# Altium schematic sheet Smartwatch_Schematic.SchDoc
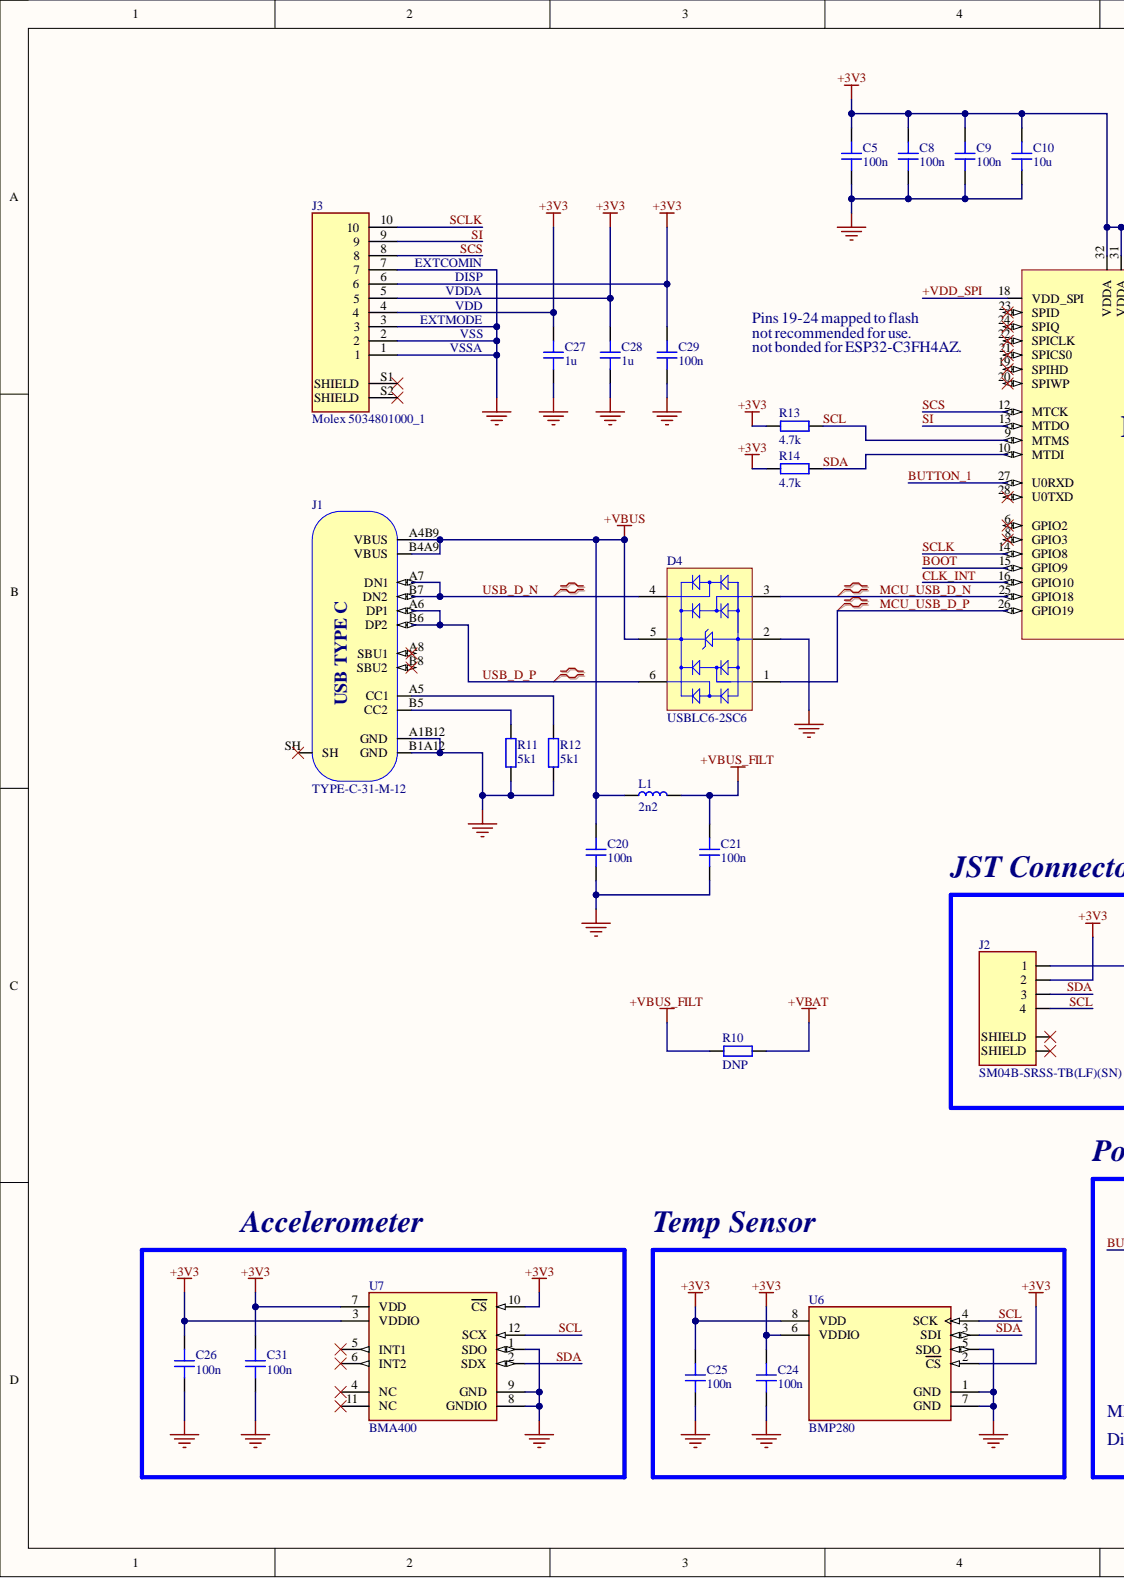
[diagram p0: Smartwatch_Schematic.SchDoc, left]
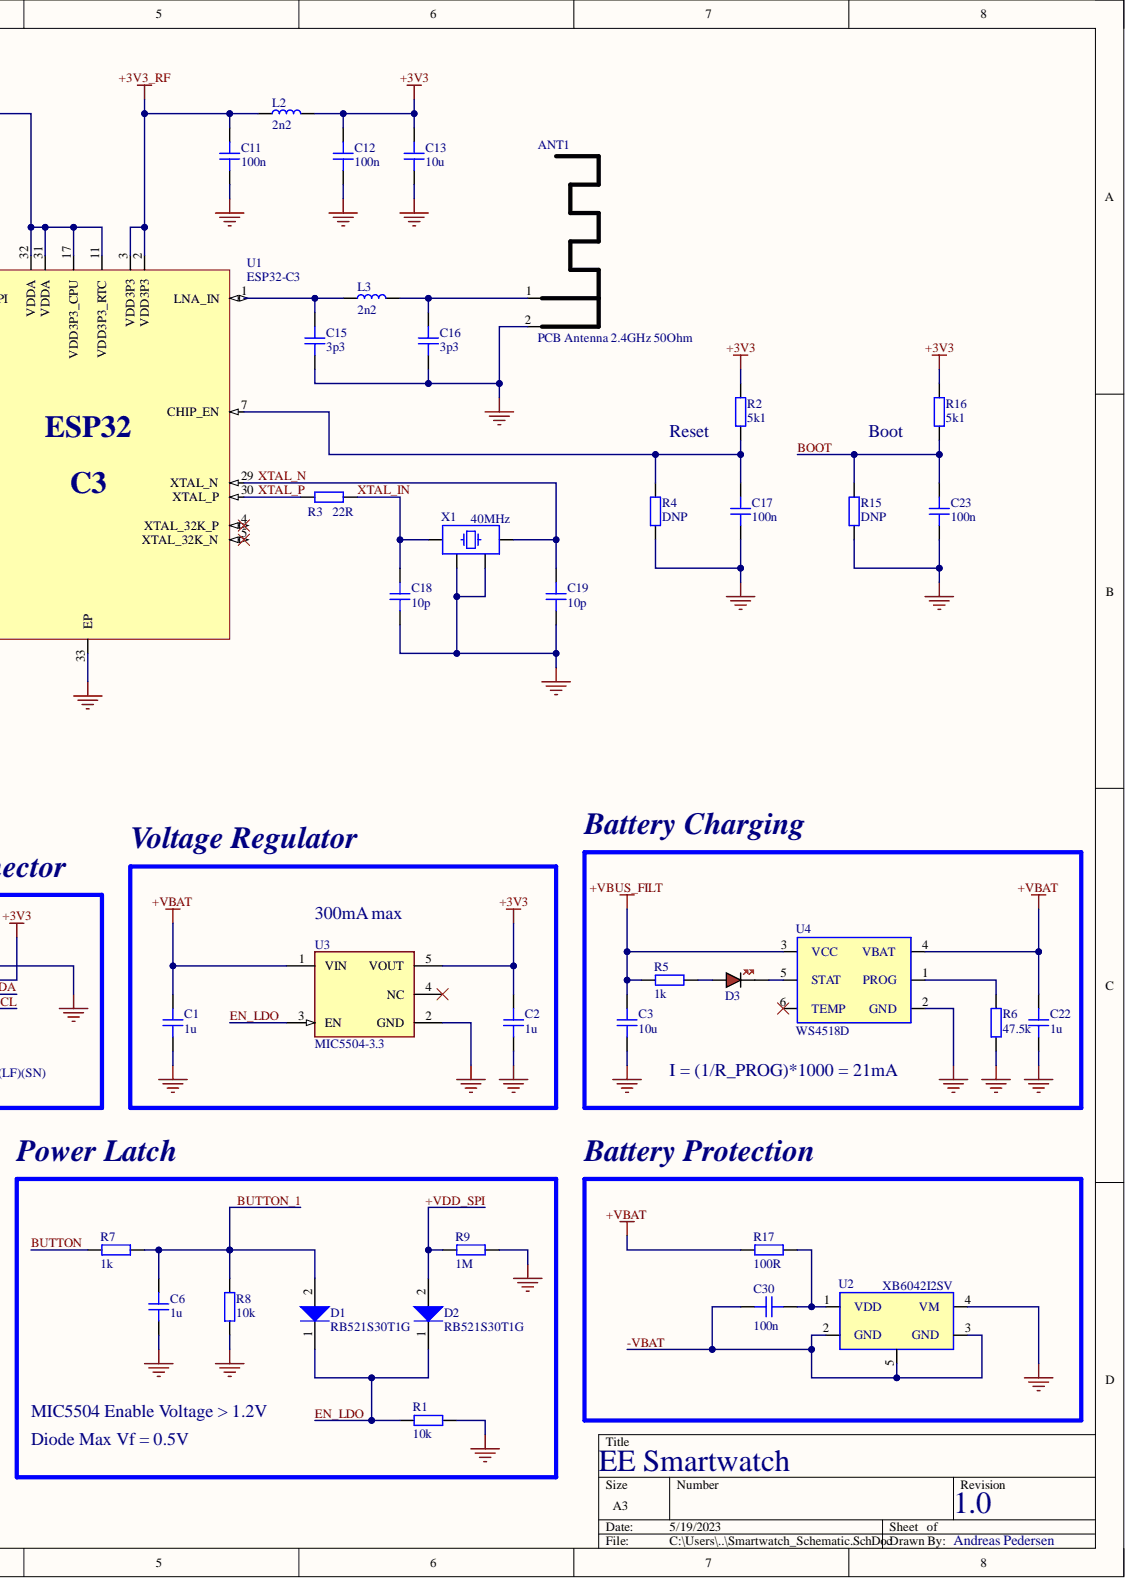
[diagram p1: Smartwatch_Schematic.SchDoc, right]

## Parts
ANT1  PCB Antenna 2.4GHz 50Ohm  [ANT_IFA_USB_2_4GHZ_50R]  (no BOM)
C1  1u  [CAP_0603]
C2  1u  [CAP_0603]
C3  10u  [CAP_0603]
C5  100n  [CAP_0402]
C6  1u  [CAP_0402]
C8  100n  [CAP_0402]
C9  100n  [CAP_0402]
C10  10u  [CAP_0603]
C11  100n  [CAP_0402]
C12  100n  [CAP_0402]
C13  10u  [CAP_0603]
C15  3p3  [CAP_0402]
C16  3p3  [CAP_0402]
C17  100n  [CAP_0402]
C18  10p  [CAP_0402]
C19  10p  [CAP_0402]
C20  100n  [CAP_0402]
C21  100n  [CAP_0402]
C22  1u  [CAP_0603]
C23  100n  [CAP_0402]
C24  100n  [CAP_0402]
C25  100n  [CAP_0402]
C26  100n  [CAP_0402]
C27  1u  [CAP_0402]
C28  1u  [CAP_0402]
C29  100n  [CAP_0402]
C30  100n  [CAP_0402]
C31  100n  [CAP_0402]
D1  RB521S30T1G  [ONSC-SOD-523-2-502-01_V]
D2  RB521S30T1G  [ONSC-SOD-523-2-502-01_V]
D3  RED  [LED_0603_RED]  (value not drawn)
D4  USBLC6-2SC6  [STM-SOT23-6L_V]
J1  TYPE-C-31-M-12  [TYPE-C-31-M-12]
J2  SM04B-SRSS-TB(LF)(SN)  [JST_SM04B-SRSS-TB(LF)(SN)]
J3  Molex 5034801000_1  MF: Molex  [MOLEX_5034801000]
L1  2n2  [IND_0603]
L2  2n2  [IND_0402]
L3  2n2  [IND_0402]
R1  10k  [RES_0402]
R2  5k1  [RES_0402]
R3  22R  [RES_0402]
R4  DNP  [RES_1206]
R5  1k  [RES_0402]
R6  47.5k  [RES_0402]
R7  1k  [RES_0402]
R8  10k  [RES_0402]
R9  1M  [RES_0402]
R10  DNP  [RES_1206]
R11  5k1  [RES_0402]
R12  5k1  [RES_0402]
R13  4.7k  [RES_0402]
R14  4.7k  [RES_0402]
R15  DNP  [RES_1206]
R16  5k1  [RES_0402]
R17  100R  [RES_0402]
U1  ESP32-C3  [QFN32-5x5]
U2  XB6042I2SV  [XDFN-4_L1.0-W1.0-P0.65-BL-EP]
U3  MIC5504-3.3  Max Output Current: 300mA  [FP-SOT23-5LD-PL-1-MFG]
U4  WS4518D  [DFN2x2-6L]
U6  BMP280  [FP-BMP280-MFG]
U7  BMA400  [FP-BMA400-MFG]
X1  40MHz  [XTAL_2520]

## Nets
+3V3: C2.2 C5.2 C8.2 C9.2 C10.2 C12.2 C13.2 C24.2 C25.2 C26.2 C27.2 C28.2 C29.2 C31.2 J2.2 J3.4 J3.5 J3.6 L2.2 R2.1 R13.1 R14.1 R16.1 U1.11(VDD3P3_RTC) U1.17(VDD3P3_CPU) U1.31(VDDA) U1.32(VDDA) U3.5(VOUT) U6.2(CS) U6.6(VDDIO) U6.8(VDD) U7.3(VDDIO) U7.7(VDD) U7.10(CS)
+3V3_RF: C11.2 L2.1 U1.2(VDD3P3) U1.3(VDD3P3)
+VBAT: C1.2 C22.2 R10.2 R17.2 U3.1(VIN) U4.4(VBAT)
+VBUS: C20.2 D4.5 J1.A4B9(VBUS) J1.B4A9(VBUS) L1.1
+VBUS_FILT: C3.2 C21.2 L1.2 R5.2 R10.1 U4.3(VCC)
GND: ANT1.2 C1.1 C2.1 C3.1 C5.1 C6.1 C8.1 C9.1 C10.1 C11.1 C12.1 C13.1 C15.1 C16.1 C17.1 C18.1 C19.1 C20.1 C21.1 C22.1 C23.1 C24.1 C25.1 C26.1 C27.1 C28.1 C29.1 C31.1 D4.2 J1.A1B12(GND) J1.B1A12(GND) J2.1 J3.1 J3.2 J3.3 J3.7 R1.1 R4.2 R6.1 R8.1 R9.1 R11.1 R12.1 R15.2 U1.33(EP) U2.4(VM) U3.2(GND) U4.2(GND) U6.1(GND) U6.5(SDO) U6.7(GND) U7.1(SDO) U7.8(GNDIO) U7.9(GND) X1.2 X1.4
+VDD_SPI: D2.2 R9.2 U1.18(VDD_SPI)
-VBAT: C30.1 U2.2(GND) U2.3(GND) U2.5
BOOT: C23.2 R15.1 R16.2 U1.15(GPIO9)
BUTTON: R7.2
BUTTON_1: C6.2 D1.2 R7.1 R8.2 U1.27(U0RXD)
CLK_INT: U1.16(GPIO10)
EN_LDO: D1.1 D2.1 R1.2 U3.3(EN)
MCU_USB_D_N: D4.3 U1.25(GPIO18)
MCU_USB_D_P: D4.1 U1.26(GPIO19)
SCL: J2.4 R13.2 U1.9(MTMS) U6.4(SCK) U7.12(SCX)
SCLK: J3.10 U1.14(GPIO8)
SCS: J3.8 U1.12(MTCK)
SDA: J2.3 R14.2 U1.10(MTDI) U6.3(SDI) U7.2(SDX)
SI: J3.9 U1.13(MTDO)
USB_D_N: D4.4 J1.A7(DN1) J1.B7(DN2)
USB_D_P: D4.6 J1.A6(DP1) J1.B6(DP2)
XTAL_IN: C18.2 R3.1 X1.1
XTAL_N: C19.2 U1.29(XTAL_N) X1.3
XTAL_P: R3.2 U1.30(XTAL_P)
NetANT1_1: ANT1.1 C16.2 L3.2
NetC15_2: C15.2 L3.1 U1.1(LNA_IN)
NetC17_2: C17.2 R2.2 R4.1 U1.7(CHIP_EN)
NetC30_2: C30.2 R17.1 U2.1(VDD)
NetD3_A: D3.A R5.1
NetD3_K: D3.K U4.5(STAT)
NetJ1_A5: J1.A5(CC1) R12.2
NetJ1_B5: J1.B5(CC2) R11.2
NetR6_2: R6.2 U4.1(PROG)

Not connected: J1 A8(SBU1) B8(SBU2) SH; J2 S1(SHIELD) S2(SHIELD); J3 S1(SHIELD) S2(SHIELD); U1 4(XTAL_32K_P) 5(XTAL_32K_N) 6(GPIO2) 8(GPIO3) 19(SPIHD) 20(SPIWP) 21(SPICS0) 22(SPICLK) 23(SPID) 24(SPIQ) 28(U0TXD); U3 4(NC); U4 6(TEMP); U7 4(NC) 5(INT1) 6(INT2) 11(NC)

## Library data of the parts
ANT1 = ANT_IFA_USB_2_4GHZ_50R: Inverted F 2.4GHz ISM PCB Antenna 50 Ohm; ComponentLink1Description: Datasheet; ComponentLink1URL: https://www.ti.com/lit/an/swra117d/swra117d.pdf; Library Name: Schematic-Lib-PhilsLab_V2.SchLib
C1-C3 C10 C13 C22 = CAP_0603_10V: Capacitor SMD 0603 10V; Component Kind: Standard
  C1-C3 C22: Library Name: Schematic-Lib-PhilsLab.SchLib
  C10 C13: Library Name: Schematic-Lib-PhilsLab_V2.SchLib
C5 C6 C8 C9 C11 C12 C15-C19 C23-C31 = CAP_0402_10V: Capacitor SMD 0402 10V; Component Kind: Standard; Library Name: Schematic-Lib-PhilsLab_V2.SchLib
C20 C21 = CAP_0603_10V: Capacitor SMD 0402 10V; Component Kind: Standard; Library Name: Schematic-Lib-PhilsLab_V2.SchLib
D1 D2 = CMP-1051-00018-1: Schottky Barrier Diode, 2-Pin SOD-523, Pb-Free, Tape and Reel; Manufacturer: On Semiconductor; Configuration: Single; IFSM Max (A): 1; Component Kind: Standard; ComponentLink1Description: Manufacturer URL; ComponentLink1URL: http://www.onsemi.com/; ComponentLink2Description: Datasheet; ComponentLink2URL: http://www.onsemi.cn/pub_link/Collateral/RB521S30T1-D.PDF; ComponentLink3Description: Package Specification; ComponentLink3URL: http://www.onsemi.com/pub_link/Collateral/502-01.PDF; DatasheetVersion: Rev. 8; Library Name: Altium Content Vault; Mounting Technology: Surface Mount; PackageDescription: 2-Pin SOD, Body 1.2 x 0.8 mm; PackageReference: SOD-523-2-502-01; PackageVersion: Rev. E, 09/2010; Packing: Tape and Reel; PartNumber: RB521S30T1G; RoHS: TRUE
D3 = LED_0603_RED: LED 0603 RED SMD; Component Kind: Standard; Library Name: Schematic-Lib-PhilsLab.SchLib
D4 = CMP-2000-05378-1: Very low capacitance ESD protection, 3.5 pF, -40 to 125 degC, 6-Pin SOT23, RoHS, Tape and Reel; Manufacturer: STMicroelectronics; Capacitance Value: 3.5pF; Clamping Voltage: 17V; Configuration: Dual; Direction Type: Uni-Directional; Leakage Current: 0.15uA; Number of Elements: 1; Peak Pulse Current: 5A; Product Depth (mm): 1.75mm; Product Height (mm): 1.3mm; Product Length (mm): 3.05mm; Rad Hardened: No; Reverse Breakdown Voltage: 6V; Reverse Stand-off Voltage: 5V; Suppressor Type: DiodeArrays; Test Current (It): 1mA; Clamping Voltage (Max): 17V; Component Kind: Standard; Datasheet URL: http://www.st.com/st-web-ui/static/active/en/resource/technical/document/datasheet/CD00050750.pdf; Datasheet Version: Rev. 5, 10/2011; Leakage Current (Max): 0.15uA; Library Name: Altium Content Vault; Manufacturer URL: http://www.st.com/; Mounting: SurfaceMount; Mounting Style: SurfaceMount; Operating Temp Range: -40Cto125C; Operating Temperature Classification: Automotive; Operating Temperature Max Deg. C: 125C; Operating Temperature Min Deg. C: -40C; Package / Case: SOT-23; Package Description: 6-Pin Small Outline Transistor, Body 2.925 x 1.625 mm, Pitch 0.95 mm; Package Reference: SOT23-6L; Packaging: TapeandReel; Pin Count: 6; Polarity: Uni-Directional; Working Voltage: 5V; Working Voltage (Max): 5V
J1 = TYPE-C-31-M-12: USB Type C Connector Horizontal SMT; Manufacturer: Koren Hroparts; Component Kind: Standard; Manufacturer Part Number: TYPE-C-31-M-12; Library Name: Schematic-Lib-PhilsLab_V2.SchLib
J2 = SM04B-SRSS-TB(LF)(SN): SH Series 1.0 mm Pitch 2 Position Single Row Side Entry Shrouded Header; Availability: Unavailable; Component Kind: Standard; Library Name: Custom_Schematic_Lib.SchLib; MP: SM04B-SRSS-TB(LF)(SN); Package: None; Price: None
J3 = Molex 5034801000_1: 10 Position FFC, FPC Connector Contacts, Top and Bottom 0.020 _0.50mm_ Surface Mount, Right Angle; Component Kind: Standard; Digi-Key_Part_Number: WM1389CT-ND; Digi-Key_Purchase_URL: https://www.digikey.com/product-detail/en/molex/5034801000/WM1389CT-ND/2356643?utm_source=snapeda&utm_medium=aggregator&utm_campaign=symbol; Library Name: Custom_Schematic_Lib.SchLib; MP: 5034801000; Package: None; SnapEDA_Link: https://www.snapeda.com/parts/5034801000/Molex/view-part/2746786/?ref=snap
L1-L3 = IND_0603: Inductor SMD 0603; Component Kind: Standard; Library Name: Schematic-Lib-PhilsLab_V2.SchLib
R1-R3 R5-R9 R11-R14 R16 R17 = RES_0402: Resistor SMD 0402; Component Kind: Standard; Library Name: Schematic-Lib-PhilsLab_V2.SchLib
R4 R10 R15 = RES_0603: Resistor SMD 0603; Component Kind: Standard; Library Name: Schematic-Lib-PhilsLab_V2.SchLib
U1 = ESP32-C3: Ultra­Low­Power SoC with RISC­V Single­Core CPU Supporting IEEE 802.11b/g/n (2.4 GHz Wi­Fi) and Bluetooth® 5 (LE); Manufacturer: Espressif; Component Kind: Standard; LCSC: C2838500; Manufacturer Part Number: ESP32-C3; Library Name: Schematic-Lib-PhilsLab_V2.SchLib
U2 = XB6042I2SV: XySemi Battery Protection DFN1x1x0.37-4; Component Kind: Standard; Library Name: Custom_Schematic_Lib.SchLib
U3 = CMP-2000-07604-2: IC REG LINEAR 3.3V 300MA SOT23-5; Accuracy: 3%; Dropout Voltage: 380mV; Max Input Voltage: 5.5V; Min Input Voltage: 2.5V; Number of Outputs: 1; Operating Supply Current: 42uA; Output Type: Fixed; Power Supply Rejection Ratio (PSRR): 60dB; Quiescent Current: 38uA; Case/Package: SOT-23-5; Component Kind: Standard; Height: 1.1mm; Length: 2.9mm; Library Name: Altium Content Vault; Max Operating Temperature: 125°C; Min Operating Temperature: -40°C; Mount: Surface Mount; Nominal Output Voltage: 3.3V; Number of Pins: 5; Output Current: 300mA; Output Voltage: 3.3V; Packaging: Tape and Reel; REACH SVHC: No SVHC; Width: 1.6mm
U4 = WS4518D: 300mA, Single Li-ion Battery Charger DFN2x2-6L; Component Kind: Standard; Library Name: Custom_Schematic_Lib.SchLib
U6 = CMP-2000-05076-3: SENSOR PRESSURE ABS; Max Pressure: 290.08PSI; Operating Pressure: 15.95PSI; Output Type: SPI; Port Style: No Port; Pressure Type: Absolute; Case/Package: SMD/SMT; Component Kind: Standard; Lead Free: Lead Free; Library Name: Altium Content Vault; Max Operating Temperature: 85°C; Min Operating Temperature: -40°C; Number of Pins: 8; Termination: SMD/SMT
U7 = CMP-191065-000001-1: ACCELEROMETER 2-16G 12LGA; Manufacturer: Bosch Sensortec; Accel Axis: X+Y and Z; Accel Range: 2/4/8/16g; Accel Resolution: 12bits; Accel Sensitivity: 128/256/512/1024LSB/g; Max Supply Voltage: 3.6V; Min Supply Voltage: 1.2V; Operating Supply Current: 14.5uA; Sensor Output: I2C,SPI; Ambient Temperature Range High: +85°C; Ambient Temperature Range Low: -40°C; Automotive: No; Category: IC; Component Kind: Standard; ComponentLink2Description: Datasheet; ComponentLink2URL: https://ae-bst.resource.bosch.com/media/_tech/media/datasheets/BST-BMA400-DS000.pdf; Device Class L1: Sensors; Device Class L2: Motion Sensors; Device Class L3: Accelerometers; Digikey Description: ACCELEROMETER 2-16G 12LGA; Height: 1mm; Lead Free: Yes; Library Name: Altium Content Vault; Manufacturer Part Number: BMA400; Mouser Description: BMA400 Series 3.6 V ±2g/4g/8g/16g 3 Axes Ultra Low Power Accelerometer - LGA-12; Octopart: https://octopart.com/bma400-bosch+sensortec-106101410; Package: 0; RoHS: TRUE; Temperature: -40°C to +85°C; Temperature Range High: +85°C; Temperature Range Low: -40°C
X1 = XTAL_2520: Crystal SMD 2520; Component Kind: Standard; Library Name: Schematic-Lib-PhilsLab_V2.SchLib
